# Altium schematic sheet 5.04.51805A02_ STMY1.SchDoc("5.04.51805A02_ STMY1")
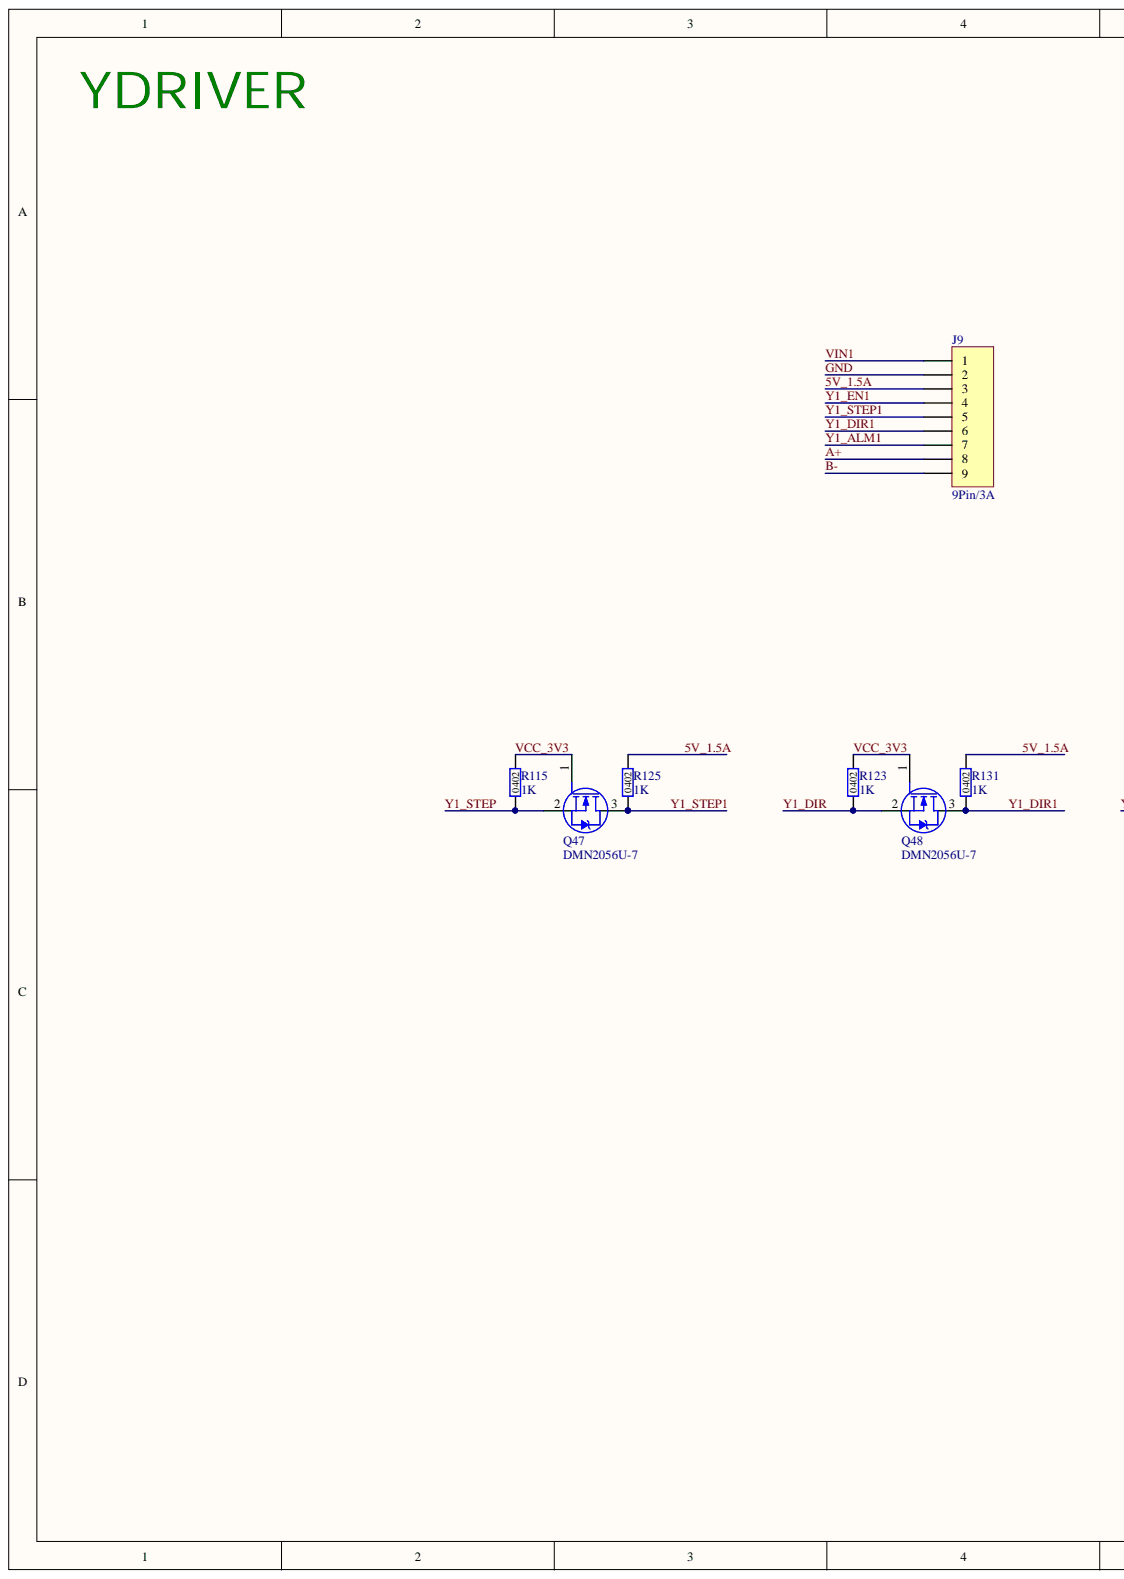
[diagram p0: 5.04.51805A02_ STMY1.SchDoc, left]
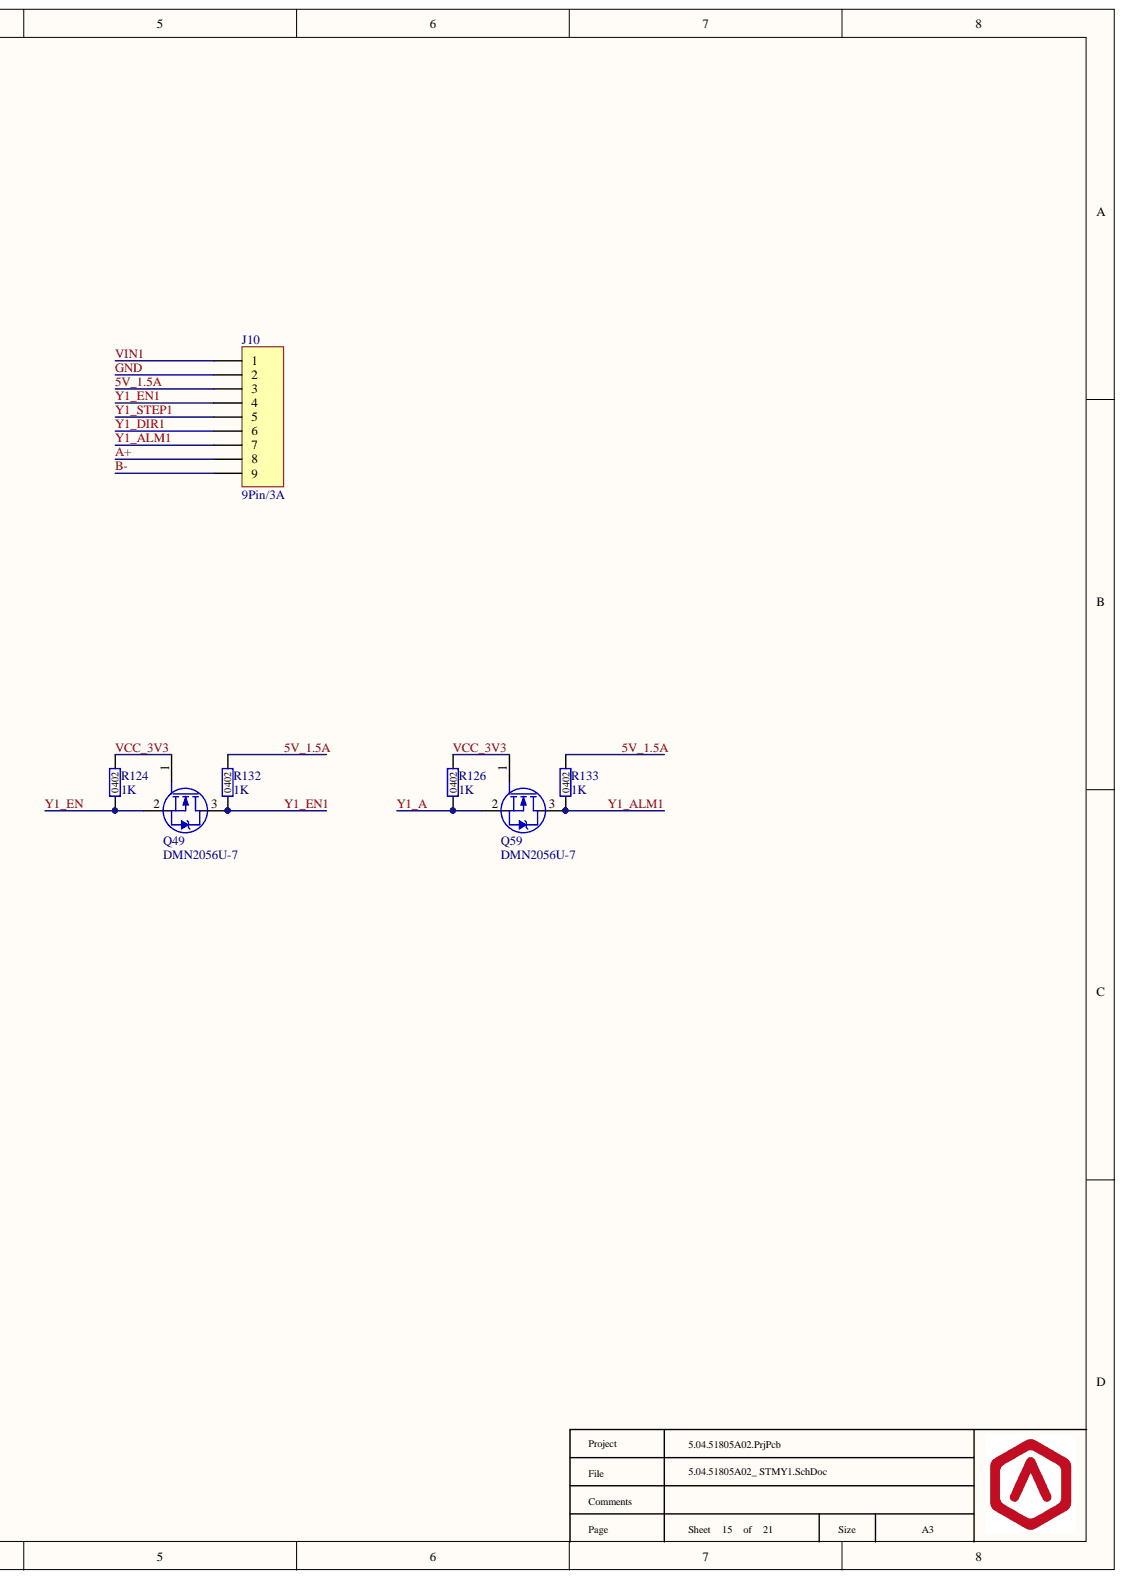
[diagram p1: 5.04.51805A02_ STMY1.SchDoc, right]

## Parts
J9  9Pin/3A  [CONN-TH_B9B-XH-A-LF-SN]
J10  9Pin/3A  [CONN-TH_B9B-XH-A-LF-SN]
Q47  DMN2056U-7  [SOT-23-3]
Q48  DMN2056U-7  [SOT-23-3]
Q49  DMN2056U-7  [SOT-23-3]
Q59  DMN2056U-7  [SOT-23-3]
R115  1K  [R0402]
R123  1K  [R0402]
R124  1K  [R0402]
R125  1K  [R0402]
R126  1K  [R0402]
R131  1K  [R0402]
R132  1K  [R0402]
R133  1K  [R0402]

## Nets
5V_1.5A: J9.3 J10.3 R125.2 R131.2 R132.2 R133.2
A+: J9.8 J10.8
B-: J9.9 J10.9
GND: J9.2 J10.2
VCC_3V3: Q47.1 Q48.1 Q49.1 Q59.1 R115.2 R123.2 R124.2 R126.2
VIN1: J9.1 J10.1
Y1_A: Q59.2 R126.1
Y1_ALM1: J9.7 J10.7 Q59.3 R133.1
Y1_DIR: Q48.2 R123.1
Y1_DIR1: J9.6 J10.6 Q48.3 R131.1
Y1_EN: Q49.2 R124.1
Y1_EN1: J9.4 J10.4 Q49.3 R132.1
Y1_STEP: Q47.2 R115.1
Y1_STEP1: J9.5 J10.5 Q47.3 R125.1

## Library data of the parts
J9 J10 = JW_B9B-XH-A(LF)(SN): 线对板连接器，9PINS,PITCH2.5mm.TH,OR,,3A,白，-25~+85℃; Manufacturer1: JST; Code: 550100XX; Component Kind: Standard; ManufacturerPartNumber1: B9B-XH-A(LF)(SN); Library Name: Raise3D_Connector.IntLib
Q47-Q49 Q59 = NMOS_DMN2056U-7: N-MOSFET,DMN2056U-7,1Channel,Vds=20V,Id=4A,Vgs=+/-8V,TO-236-3,-55°C~150°C; Manufacturer1: DIODES; Code: 54010011; Component Kind: Standard; ManufacturerPartNumber1: DMN2056U-7; Library Name: Raise3D_MOS&BJT.IntLib
R115 R123-R126 R131-R133 = R0402_1K: Resistor 1Kohm,5%,1/16W,R0402; Manufacturer1: Yageo; ManufacturerPartNumber1: RC0402JR-071KL; Code: 5011001K; Component Kind: Standard
  R115 R123-R125 R131-R133: Library Name: Raise3D_Resistor.SCHLIB
  R126: Library Name: Raise3D_Resistor.IntLib
